# Altium schematic sheet Clocking.SchDoc(Clocking)
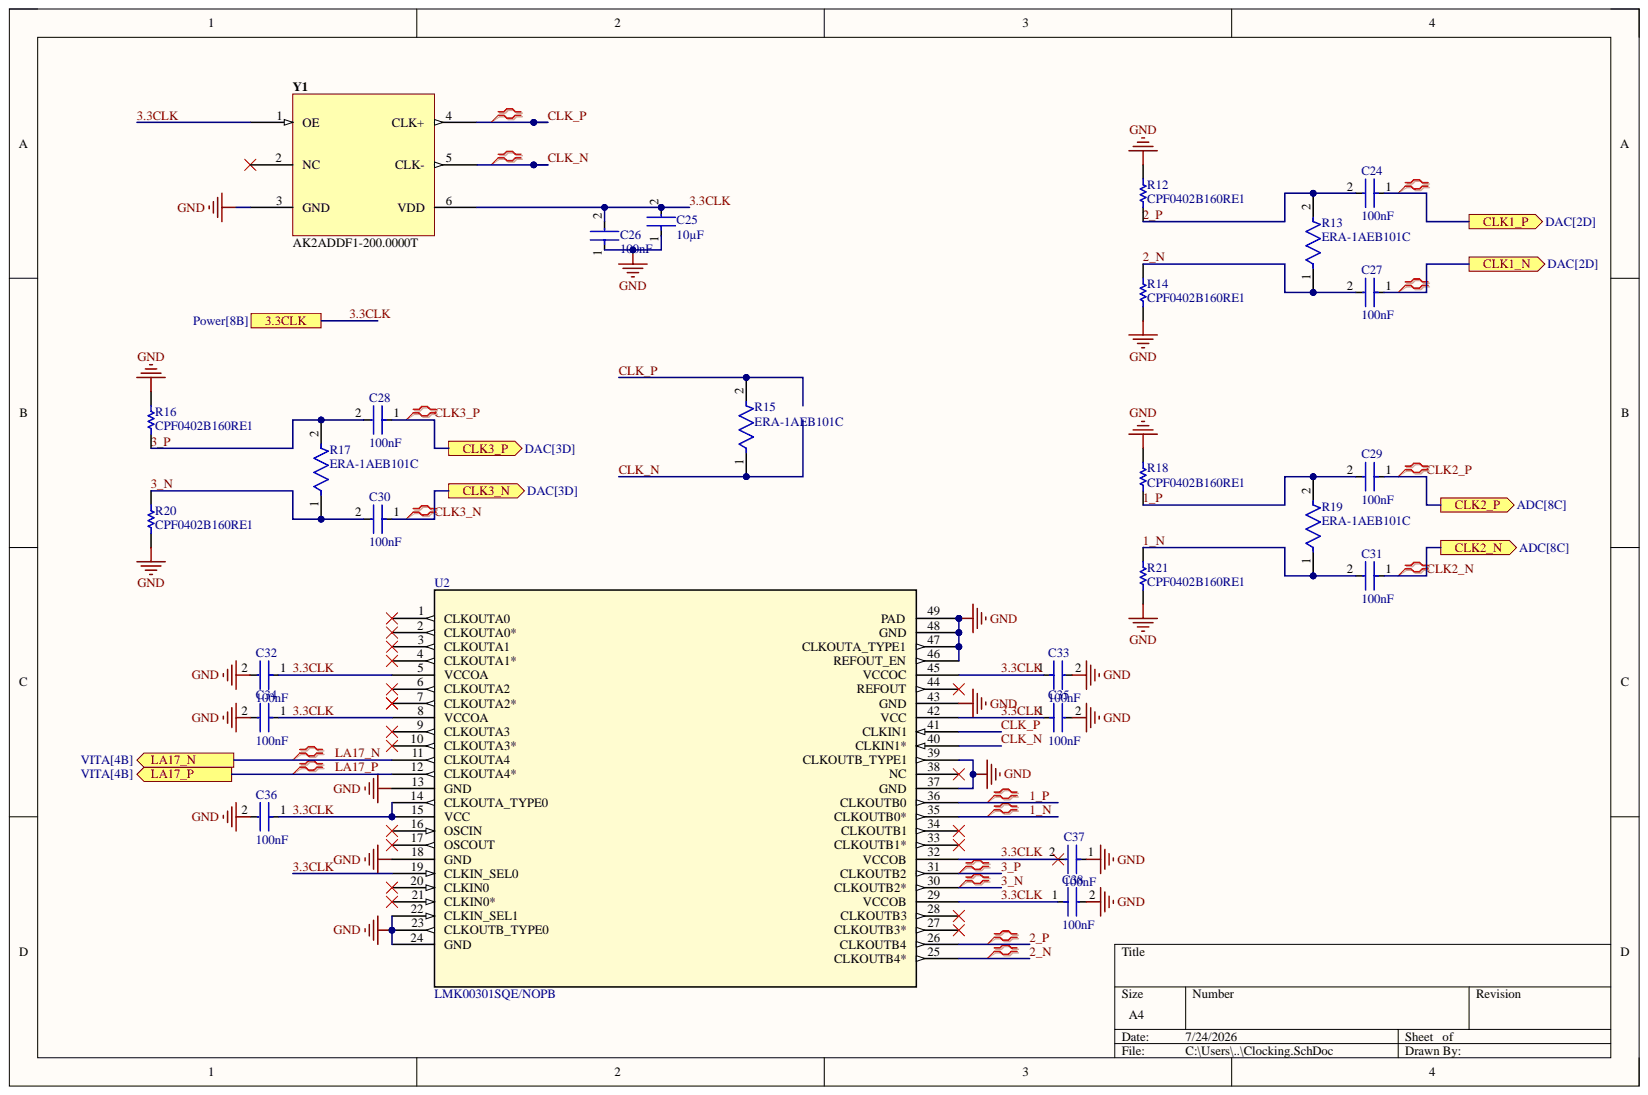
[diagram p0: Clocking.SchDoc]

## Parts
C24  value 100nF  [FP-GRM033-0_03-MFG]  (hidden comment: GRM033Z71C104KE14D)
C25  value 10µF  [FP-GRM188-0_2-IPC_C]  (hidden comment: GRM188R61E106KA73D)
C26  value 100nF  [FP-GRM033-0_03-MFG]  (hidden comment: GRM033Z71C104KE14D)
C27  value 100nF  [FP-GRM033-0_03-MFG]  (hidden comment: GRM033Z71C104KE14D)
C28  value 100nF  [FP-GRM033-0_03-MFG]  (hidden comment: GRM033Z71C104KE14D)
C29  value 100nF  [FP-GRM033-0_03-MFG]  (hidden comment: GRM033Z71C104KE14D)
C30  value 100nF  [FP-GRM033-0_03-MFG]  (hidden comment: GRM033Z71C104KE14D)
C31  value 100nF  [FP-GRM033-0_03-MFG]  (hidden comment: GRM033Z71C104KE14D)
C32  value 100nF  [FP-GRM033-0_03-MFG]  (hidden comment: GRM033Z71C104KE14D)
C33  value 100nF  [FP-GRM033-0_03-MFG]  (hidden comment: GRM033Z71C104KE14D)
C34  value 100nF  [FP-GRM033-0_03-MFG]  (hidden comment: GRM033Z71C104KE14D)
C35  value 100nF  [FP-GRM033-0_03-MFG]  (hidden comment: GRM033Z71C104KE14D)
C36  value 100nF  [FP-GRM033-0_03-MFG]  (hidden comment: GRM033Z71C104KE14D)
C37  value 100nF  [FP-GRM033-0_03-MFG]  (hidden comment: GRM033Z71C104KE14D)
C38  value 100nF  [FP-GRM033-0_03-MFG]  (hidden comment: GRM033Z71C104KE14D)
R12  CPF0402B160RE1  [RESC1005X35X20NL05T10]
R13  ERA-1AEB101C  [FP-ERA1A-IPC_C]
R14  CPF0402B160RE1  [RESC1005X35X20NL05T10]
R15  ERA-1AEB101C  [FP-ERA1A-IPC_C]
R16  CPF0402B160RE1  [RESC1005X35X20NL05T10]
R17  ERA-1AEB101C  [FP-ERA1A-IPC_C]
R18  CPF0402B160RE1  [RESC1005X35X20NL05T10]
R19  ERA-1AEB101C  [FP-ERA1A-IPC_C]
R20  CPF0402B160RE1  [RESC1005X35X20NL05T10]
R21  CPF0402B160RE1  [RESC1005X35X20NL05T10]
U2  LMK00301SQE/NOPB  [SQA48A]
Y1  AK2ADDF1-200.0000T  [PSON100P200X100-6N]

## Ports
3.3CLK, CLK1_N, CLK1_P, CLK2_N, CLK2_P, CLK3_N, CLK3_P, LA17_N, LA17_P

## Nets
GND: C25.1 C26.1 C32.2 C33.2 C34.2 C35.2 C36.2 C37.1 C38.2 R12.2 R14.1 R16.2 R18.2 R20.1 R21.1 U2.13(GND) U2.18(GND) U2.22(CLKIN_SEL1) U2.23(CLKOUTB_TYPE0) U2.24(GND) U2.37(GND) U2.39(CLKOUTB_TYPE1) U2.43(GND) U2.46(REFOUT_EN) U2.47(CLKOUTA_TYPE1) U2.48(GND) U2.49(PAD) Y1.3(GND)
1_N: C31.2 R19.1 R21.2 U2.35(CLKOUTB0*)
1_P: C29.2 R18.1 R19.2 U2.36(CLKOUTB0)
2_N: C27.2 R13.1 R14.2 U2.25(CLKOUTB4*)
2_P: C24.2 R12.1 R13.2 U2.26(CLKOUTB4)
3.3CLK: C25.2 C26.2 C32.1 C33.1 C34.1 C35.1 C36.1 C37.2 C38.1 U2.5(VCCOA) U2.8(VCCOA) U2.14(CLKOUTA_TYPE0) U2.15(VCC) U2.19(CLKIN_SEL0) U2.29(VCCOB) U2.32(VCCOB) U2.42(VCC) U2.45(VCCOC) Y1.1(OE) Y1.6(VDD) ; port 3.3CLK
3_N: C30.2 R17.1 R20.2 U2.30(CLKOUTB2*)
3_P: C28.2 R16.1 R17.2 U2.31(CLKOUTB2)
CLK1_N: C27.1 ; port CLK1_N
CLK1_P: C24.1 ; port CLK1_P
CLK2_N: C31.1 ; port CLK2_N
CLK2_P: C29.1 ; port CLK2_P
CLK3_N: C30.1 ; port CLK3_N
CLK3_P: C28.1 ; port CLK3_P
CLK_N: R15.1 U2.40(CLKIN1*) Y1.5(CLK-)
CLK_P: R15.2 U2.41(CLKIN1) Y1.4(CLK+)
LA17_N: U2.11(CLKOUTA4) ; port LA17_N
LA17_P: U2.12(CLKOUTA4*) ; port LA17_P

Not connected: U2 1(CLKOUTA0) 2(CLKOUTA0*) 3(CLKOUTA1) 4(CLKOUTA1*) 6(CLKOUTA2) 7(CLKOUTA2*) 9(CLKOUTA3) 10(CLKOUTA3*) 16(OSCIN) 17(OSCOUT) 20(CLKIN0) 21(CLKIN0*) 27(CLKOUTB3*) 28(CLKOUTB3) 33(CLKOUTB1*) 34(CLKOUTB1) 38(NC) 44(REFOUT); Y1 2(NC)

## Library data of the parts
C24 C26-C38 = CMP-001-00085-1: 16V 100nF X7R ±10% 0201 Multilayer Ceramic Capacitors MLCC - SMD/SMT ROHS; Case/Package: 0201; Component Kind: Standard; Max Operating Temperature: 125°C; Min Operating Temperature: -55°C; Mounting Technology: Surface Mount; Tolerance: 10%; Voltage Rating: 16V; Library Name: Alex Clunan
C25 = CMP-001-00086-1: 25V 10uF X5R ±10% 0603 Multilayer Ceramic Capacitors MLCC - SMD/SMT ROHS; Case/Package: 0603; Component Kind: Standard; Max Operating Temperature: 85°C; Min Operating Temperature: -55°C; Mounting Technology: Surface Mount; Tolerance: 10%; Voltage Rating: 25V; Library Name: Alex Clunan
R12 R14 R16 R18 R20 R21 = CMP-2002-03443-1: Manufacturer: TE Connectivity / AMP; Component Kind: Standard; Library Name: Altium Content Vault; Part Number: CPF0402B160RE1
R13 R15 R17 R19 = CMP-05422-000095-1: RES SMD 100 OHM 0.1% 1/20W 0201; Max Power Dissipation: 50mW; Resistance: 100R; Temperature Coefficient: 25ppm/°C; Case Code (Imperial): 0201; Case Code (Metric): 0603; Case/Package: 0201; Component Kind: Standard; Features: Automotive AEC-Q200; Height: 0.009inch; Lead Free: Lead Free; Length: 0.024inch; Library Name: Altium Content Vault; Max Operating Temperature: 155°C; Min Operating Temperature: -55°C; Number of Terminations: 2; Packaging: Tape and Reel; Resistor Type: Thin Film; Tolerance: 0.1%; Width: 0.012inch
U2 = LMK00301SQENOPB: 3-GHz, 10-output differential fanout buffer / level translator 48-WQFN -40 to 85; Manufacturer_Name: Texas Instruments; Component Kind: Standard; Library Name: LMK00301SQENOPB.IntLib; Manufacturer_Part_Number: LMK00301SQE/NOPB; RefDes: RefDes; Type: Type; Copyright: Copyright (C) 2025 Ultra Librarian. All rights reserved.
Y1 = CMP-003-00032-1: LVDS Output Clock Oscillator, 200MHz Nom; Component Kind: Standard; Frequency: 200MHz; Max Operating Temperature: 85°C; Min Operating Temperature: -40°C; Mounting Technology: Surface Mount; Library Name: Alex Clunan
